# Altium schematic sheet SensorBoard.SchDoc(SensorBoard)
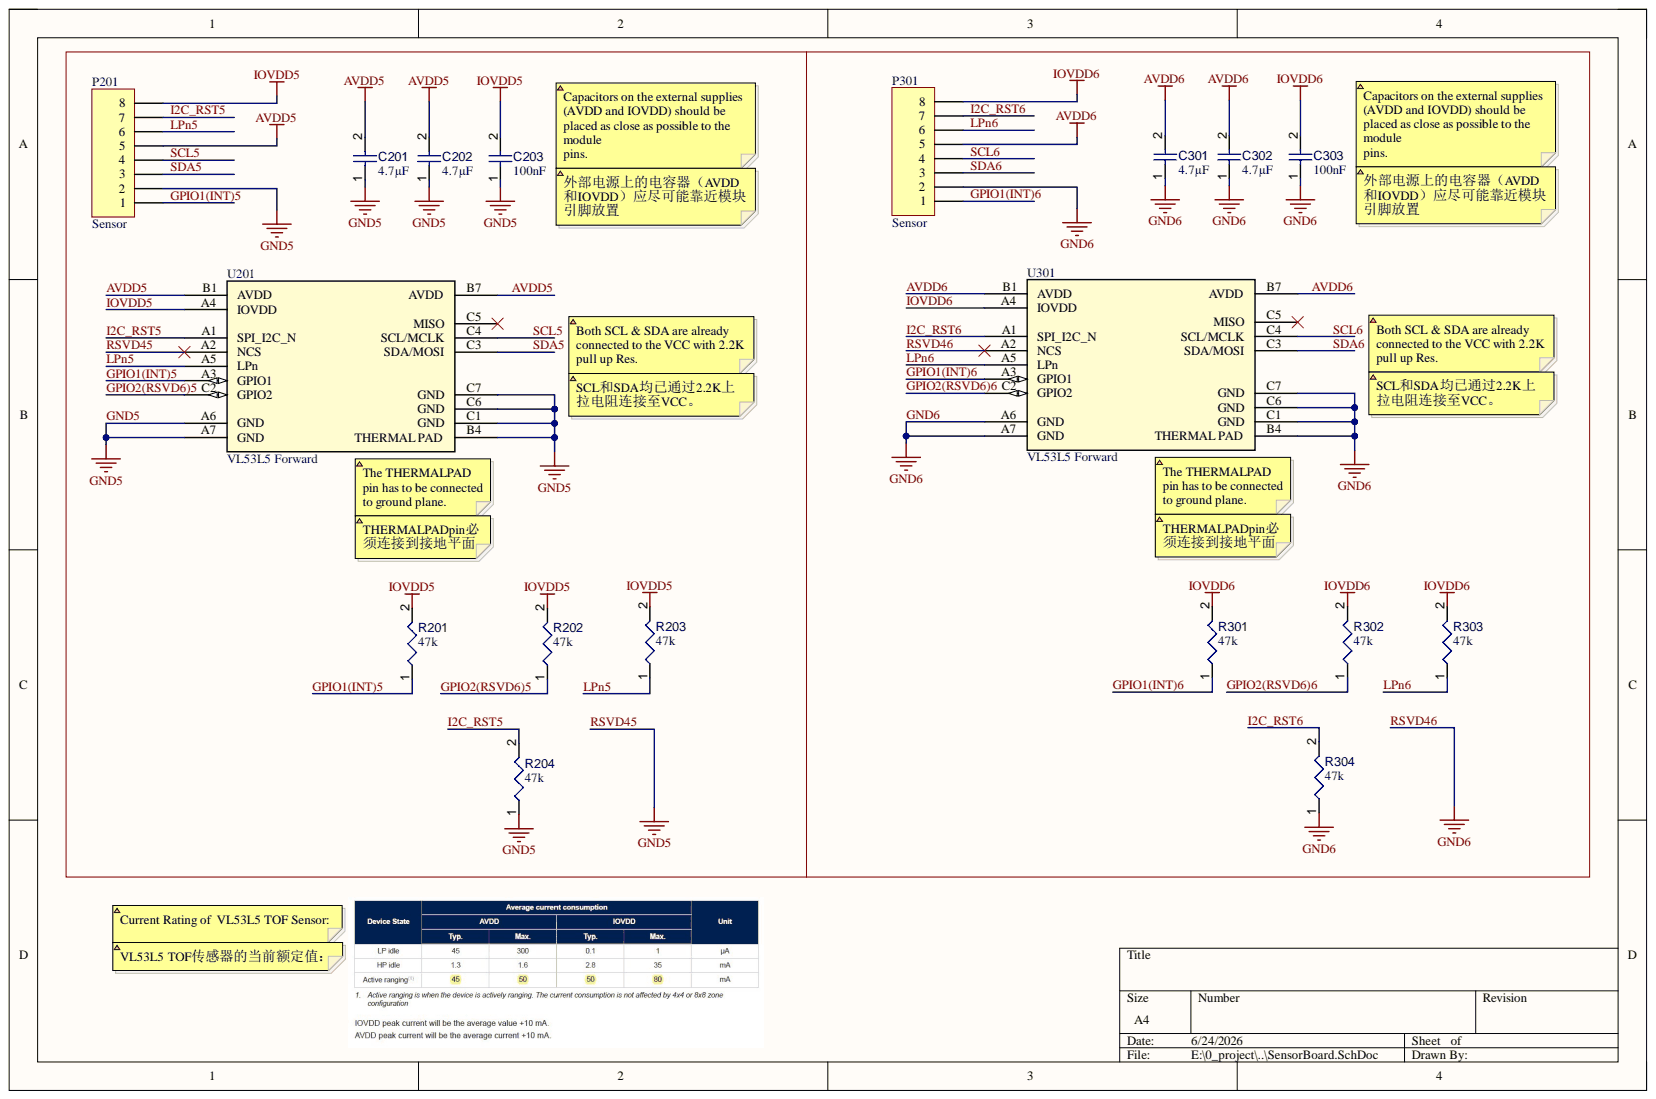
[diagram p0: SensorBoard.SchDoc]

## Parts
C201  value 4.7µF  [CAPC1005X55X25ML5T10]  (hidden comment: Capacitor 1 uF +/- 10% 6.3 V 1005)
C202  value 4.7µF  [CAPC1005X55X25ML5T10]  (hidden comment: Capacitor 1 uF +/- 10% 6.3 V 1005)
C203  value 100nF  [CAPC0402(1005)60_L]  (hidden comment: Capacitor 100nF +/-20% 25V 0402)
C301  value 4.7µF  [CAPC1005X55X25ML5T10]  (hidden comment: Capacitor 1 uF +/- 10% 6.3 V 1005)
C302  value 4.7µF  [CAPC1005X55X25ML5T10]  (hidden comment: Capacitor 1 uF +/- 10% 6.3 V 1005)
C303  value 100nF  [CAPC0402(1005)60_L]  (hidden comment: Capacitor 100nF +/-20% 25V 0402)
P201  Sensor  [PCBComponent_1]
P301  Sensor  [PCBComponent_1]
R201  value 47k  [RESC0402(1005)_L]  (hidden comment: Resistor 47K5 +/-1 % 0402 63 mW)
R202  value 47k  [RESC0402(1005)_L]  (hidden comment: Resistor 47K5 +/-1 % 0402 63 mW)
R203  value 47k  [RESC0402(1005)_L]  (hidden comment: Resistor 47K5 +/-1 % 0402 63 mW)
R204  value 47k  [RESC0402(1005)_L]  (hidden comment: Resistor 47K5 +/-1 % 0402 63 mW)
R301  value 47k  [RESC0402(1005)_L]  (hidden comment: Resistor 47K5 +/-1 % 0402 63 mW)
R302  value 47k  [RESC0402(1005)_L]  (hidden comment: Resistor 47K5 +/-1 % 0402 63 mW)
R303  value 47k  [RESC0402(1005)_L]  (hidden comment: Resistor 47K5 +/-1 % 0402 63 mW)
R304  value 47k  [RESC0402(1005)_L]  (hidden comment: Resistor 47K5 +/-1 % 0402 63 mW)
U201  VL53L5 Forward  [ARRAY_53L5_STM]
U301  VL53L5 Forward  [ARRAY_53L5_STM]

## Nets
AVDD5: C201.2 C202.2 P201.5 U201.B1(AVDD) U201.B7(AVDD)
AVDD6: C301.2 C302.2 P301.5 U301.B1(AVDD) U301.B7(AVDD)
GND5: C201.1 C202.1 C203.1 P201.2 R204.1 U201.A2(NCS) U201.A6(GND) U201.A7(GND) U201.B4(THERMAL_PAD) U201.C1(GND) U201.C6(GND) U201.C7(GND)
GND6: C301.1 C302.1 C303.1 P301.2 R304.1 U301.A2(NCS) U301.A6(GND) U301.A7(GND) U301.B4(THERMAL_PAD) U301.C1(GND) U301.C6(GND) U301.C7(GND)
GPIO1(INT)5: P201.1 R201.1 U201.A3(GPIO1)
GPIO1(INT)6: P301.1 R301.1 U301.A3(GPIO1)
GPIO2(RSVD6)5: R202.1 U201.C2(GPIO2)
GPIO2(RSVD6)6: R302.1 U301.C2(GPIO2)
I2C_RST5: P201.7 R204.2 U201.A1(SPI_I2C_N)
I2C_RST6: P301.7 R304.2 U301.A1(SPI_I2C_N)
IOVDD5: C203.2 P201.8 R201.2 R202.2 R203.2 U201.A4(IOVDD)
IOVDD6: C303.2 P301.8 R301.2 R302.2 R303.2 U301.A4(IOVDD)
LPn5: P201.6 R203.1 U201.A5(LPn)
LPn6: P301.6 R303.1 U301.A5(LPn)
SCL5: P201.4 U201.C4(SCL/MCLK)
SCL6: P301.4 U301.C4(SCL/MCLK)
SDA5: P201.3 U201.C3(SDA/MOSI)
SDA6: P301.3 U301.C3(SDA/MOSI)

Not connected: U201 C5(MISO); U301 C5(MISO)

## Library data of the parts
C201 C202 C301 C302 = CMP-001-00009-6: Chip Capacitor, 1 uF, +/- 10%, 6.3 V, 1005 (0402 Metric); Capacitor Type: Ceramic; Voltage Rating: 6.3V; Case/Package: 0402; Component Kind: Standard; Max Operating Temperature: 85°C; Min Operating Temperature: -55°C; Mounting Technology: SM; Pins: 2; RoHS Compliant: True; Tolerance: 10%; Library Name: ETH Zurich
C203 C303 = CMP-001-00065-4: Chip Capacitor, 100nF +/-20%, 25V, 0402, Thickness 0.6 mm; Tolerance: 10%; Case/Package: 0402; Component Kind: Standard; Max Operating Temperature: 85°C; Min Operating Temperature: -55°C; Mounting Technology: SMT; Pins: 2; Voltage Rating: 25V; Library Name: ETH Zurich
P201 P301 = MHDR1X8: Header, 8-Pin; System: Connector; Component Kind: Standard; LatestRevisionDate: 17-Jul-2002; LatestRevisionNote: Re-released for DXP Platform.; Library Name: Miscellaneous Connectors.IntLib; Signal Integrity: Connector; Publisher: Altium Limited
R201-R204 R301-R304 = CMP-009-00137-3: Chip Resistor, 47,5 kOhms, +/-1 %, 63 mW, -55 to 155 degC, 0402; Power: 63mW; Tolerance: 1%; Case/Package: 0402; Component Kind: Standard; Max Operating Temperature: 155°C; Min Operating Temperature: -55°C; Pins: 2; Library Name: ETH Zurich
U201 U301 = VL53L5: No Description Available; Built_By: EMA_Matt; SourceLibrary: Update_to_database_2021-02-23; Vendor: STMicroelectronics; Component Kind: Standard; Datasheet: https://www.st.com/resource/en/data_brief/vl53l5.pdf; Library Name: VL53L5.IntLib; Manufacturer_Part_Number: VL53L5; RefDes: RefDes; Type: DEV; Copyright: Copyright (C) 2018 Accelerated Designs. All rights reserved
